# Altium schematic sheet SM1.SchDoc(SM3)
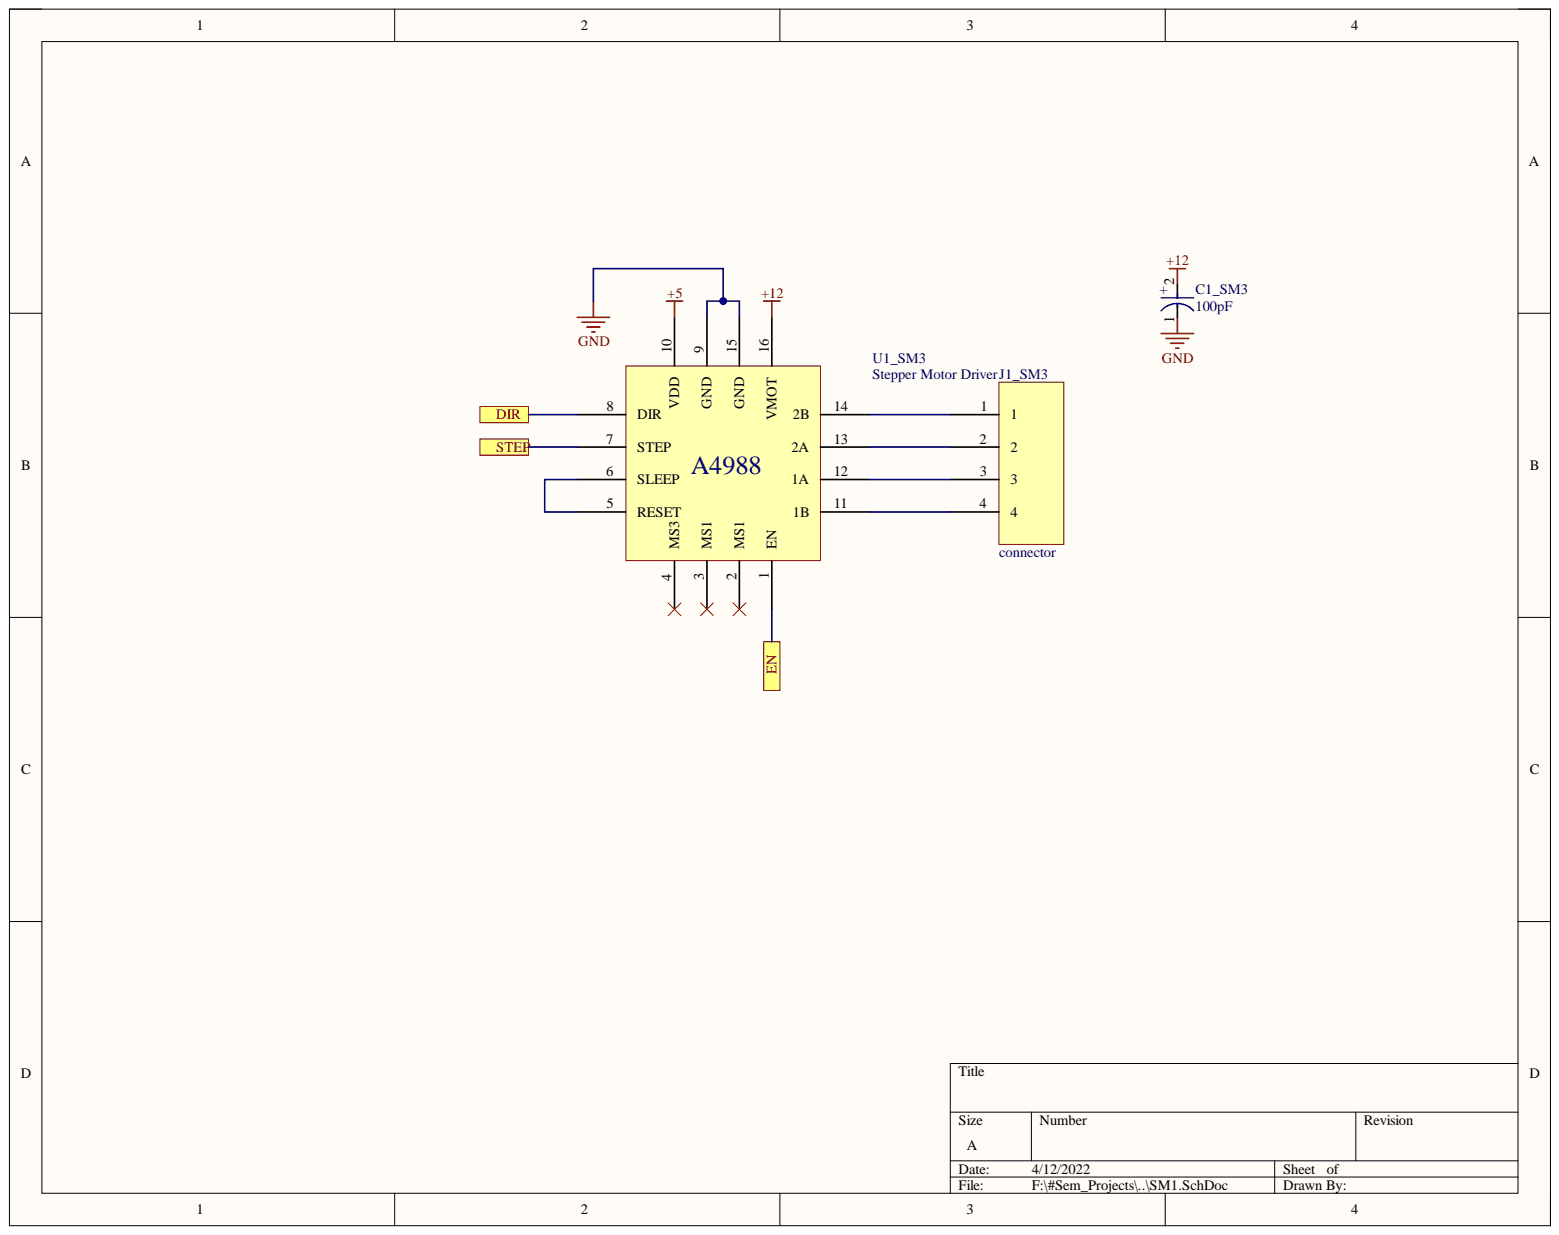
[diagram p0: SM1.SchDoc]

## Parts
C1_SM3  100pF  [FP-SC-MFG]
J1_SM3  connector  [JST_4P]
U1_SM3  Stepper Motor Driver  [A4988]

## Ports
DIR_SM3, EN_SM3, STEP_SM3

## Nets
+5: U1_SM3.10(VDD)
+12: C1_SM3.2 U1_SM3.16(VMOT)
GND: C1_SM3.1 U1_SM3.9(GND) U1_SM3.15(GND)
NetJ1_SM3_1: J1_SM3.1 U1_SM3.14(2B)
NetJ1_SM3_2: J1_SM3.2 U1_SM3.13(2A)
NetJ1_SM3_3: J1_SM3.3 U1_SM3.12(1A)
NetJ1_SM3_4: J1_SM3.4 U1_SM3.11(1B)
NetU1_SM3_1: U1_SM3.1(EN) ; port EN_SM3
NetU1_SM3_2: U1_SM3.2(MS1)
NetU1_SM3_3: U1_SM3.3(MS1)
NetU1_SM3_4: U1_SM3.4(MS3)
NetU1_SM3_5: U1_SM3.5(RESET) U1_SM3.6(SLEEP)
NetU1_SM3_7: U1_SM3.7(STEP) ; port STEP_SM3
NetU1_SM3_8: U1_SM3.8(DIR) ; port DIR_SM3

## Library data of the parts
C1_SM3 = CMP-05427-000087-1: CAP ALUM 10UF 20% 35V SMD; Lead Length: 2.2mm; Leakage Current: 3uA; Life (Hours): 2000Hour; Ripple Current: 30mA; Capacitance: 10uF; Case/Package: Radial; Component Kind: Standard; Construction: Cylindrical; Contact Plating: Tin; Diameter: 5mm; Height: 5.4mm; Height - Seated (Max): 0.213inch; Lead Pitch: 1.5mm; Length: 5.5mm; Library Name: Altium Content Vault; Max Operating Temperature: 85°C; Min Operating Temperature: -40°C; Mount: Surface Mount; Number of Pins: 2; Package Quantity: 1; Packaging: Tape and Reel; Radiation Hardening: No; REACH SVHC: No SVHC; Ripple Current (AC): 30mA; RoHS Compliant: Yes; Series: S; Termination: SMD/SMT; Tolerance: 20%; Voltage: 35V; Voltage Rating: 35V; Voltage Rating (DC): 35V; Width: 5.3mm
J1_SM3 = JST_4P: Component Kind: Standard; Library Name: Schlib.SchLib
U1_SM3 = A4988: Component Kind: Standard; Library Name: Schlib.SchLib
